# Altium schematic sheet MIPI.SchDoc
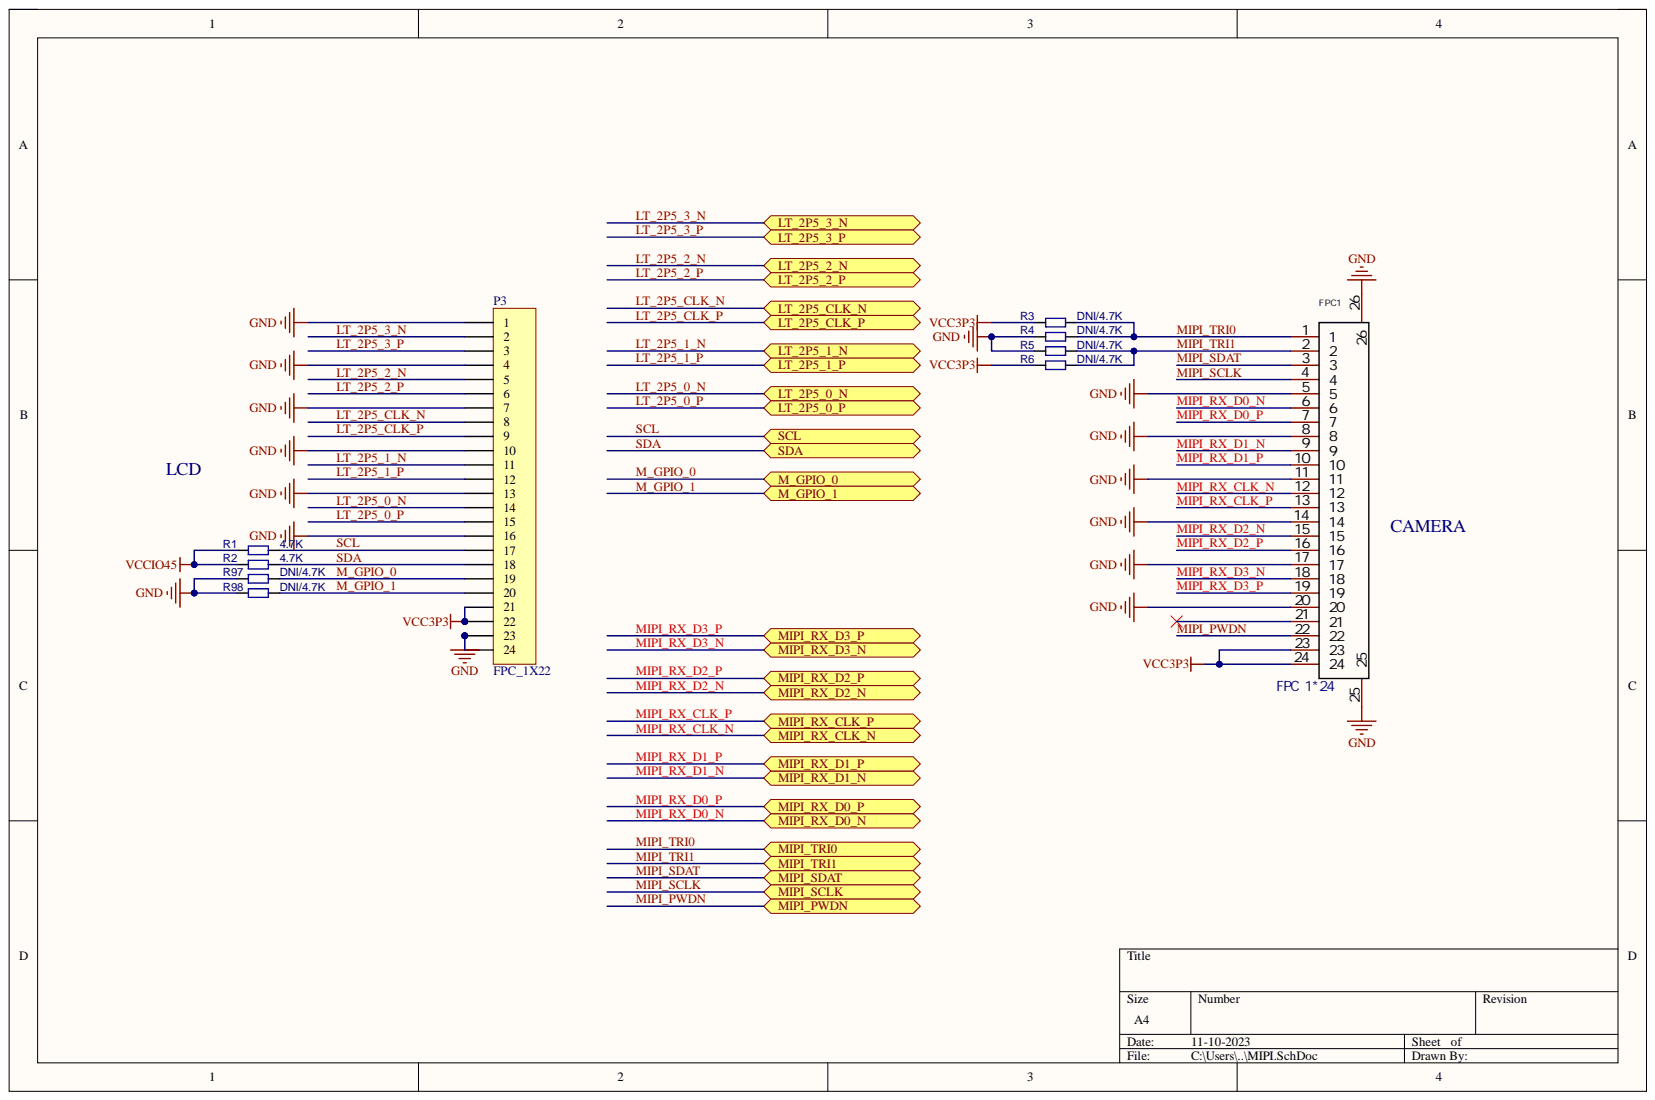
[diagram p0: MIPI.SchDoc]

## Parts
FPC1  FPC 1*24  [FPC24-0R5MM]
P3  FPC_1X22  [FPC22-0R5MM]
R1  4.7K  [R0402]
R2  4.7K  [R0402]
R3  DNI/4.7K  [R0402]
R4  DNI/4.7K  [R0402]
R5  DNI/4.7K  [R0402]
R6  DNI/4.7K  [R0402]
R97  DNI/4.7K  [R0402]
R98  DNI/4.7K  [R0402]

## Ports
LT_2P5_0_N, LT_2P5_0_P, LT_2P5_1_N, LT_2P5_1_P, LT_2P5_2_N, LT_2P5_2_P, LT_2P5_3_N, LT_2P5_3_P, LT_2P5_CLK_N, LT_2P5_CLK_P, M_GPIO_0, M_GPIO_1, MIPI_PWDN, MIPI_RX_CLK_N, MIPI_RX_CLK_P, MIPI_RX_D0_N, MIPI_RX_D0_P, MIPI_RX_D1_N, MIPI_RX_D1_P, MIPI_RX_D2_N, MIPI_RX_D2_P, MIPI_RX_D3_N, MIPI_RX_D3_P, MIPI_SCLK, MIPI_SDAT, MIPI_TRI0, MIPI_TRI1, SCL, SDA

## Nets
GND: FPC1.5 FPC1.8 FPC1.11 FPC1.14 FPC1.17 FPC1.20 FPC1.25 FPC1.26 P3.1 P3.4 P3.7 P3.10 P3.13 P3.16 P3.23 P3.24 R4.1 R5.1 R97.1 R98.1
VCC3P3: FPC1.23 FPC1.24 P3.21 P3.22 R3.1 R6.1
VCCIO45: R1.1 R2.1
LT_2P5_0_N: P3.14 ; port LT_2P5_0_N
LT_2P5_0_P: P3.15 ; port LT_2P5_0_P
LT_2P5_1_N: P3.11 ; port LT_2P5_1_N
LT_2P5_1_P: P3.12 ; port LT_2P5_1_P
LT_2P5_2_N: P3.5 ; port LT_2P5_2_N
LT_2P5_2_P: P3.6 ; port LT_2P5_2_P
LT_2P5_3_N: P3.2 ; port LT_2P5_3_N
LT_2P5_3_P: P3.3 ; port LT_2P5_3_P
LT_2P5_CLK_N: P3.8 ; port LT_2P5_CLK_N
LT_2P5_CLK_P: P3.9 ; port LT_2P5_CLK_P
M_GPIO_0: P3.19 R97.2 ; port M_GPIO_0
M_GPIO_1: P3.20 R98.2 ; port M_GPIO_1
MIPI_PWDN: FPC1.22 ; port MIPI_PWDN
MIPI_RX_CLK_N: FPC1.12 ; port MIPI_RX_CLK_N
MIPI_RX_CLK_P: FPC1.13 ; port MIPI_RX_CLK_P
MIPI_RX_D0_N: FPC1.6 ; port MIPI_RX_D0_N
MIPI_RX_D0_P: FPC1.7 ; port MIPI_RX_D0_P
MIPI_RX_D1_N: FPC1.9 ; port MIPI_RX_D1_N
MIPI_RX_D1_P: FPC1.10 ; port MIPI_RX_D1_P
MIPI_RX_D2_N: FPC1.15 ; port MIPI_RX_D2_N
MIPI_RX_D2_P: FPC1.16 ; port MIPI_RX_D2_P
MIPI_RX_D3_N: FPC1.18 ; port MIPI_RX_D3_N
MIPI_RX_D3_P: FPC1.19 ; port MIPI_RX_D3_P
MIPI_SCLK: FPC1.4 ; port MIPI_SCLK
MIPI_SDAT: FPC1.3 ; port MIPI_SDAT
MIPI_TRI0: FPC1.1 R3.2 R4.2 ; port MIPI_TRI0
MIPI_TRI1: FPC1.2 R5.2 R6.2 ; port MIPI_TRI1
SCL: P3.17 R1.2 ; port SCL
SDA: P3.18 R2.2 ; port SDA

Not connected: FPC1 21

## Library data of the parts
FPC1 = AFC01-S24FCA-00: Manufacturer: JS(钜硕电子); Add into BOM: yes; FFC, FCB Thickness: 0.3mm; Locking Feature: 翻盖式; Number of Contacts: 24P; Pitch: 0.5mm; Component Kind: Standard; Contact Type: 下接; Convert to PCB: yes; Datasheet: https://atta.szlcsc.com/upload/public/pdf/source/20190816/C413942_2A72D1AC756EAE17EEDF756A339D4500.pdf; Description: FPC 1*24; Device: AFC01-S24FCA-00; Height Above Board: 2mm; JLCPCB Part Class: Extended Part; LCSC Part Name: 0.5mm P数:24P 翻盖式 下接; Manufacturer Part: AFC01-S24FCA-00; Mounting Type: 卧贴; Name: AFC01-S24FCA-00; PCB3D: FPC-SMD_P0.50-WS-24P; Supplier: LCSC; Supplier Footprint: SMD,P=0.5mm; Supplier Part: C262669; Symbol: AFC01-S24FCA-00
P3 = Header 24: FPC 1*22; Component Kind: Standard; LatestRevisionDate: 17-Jul-2002; LatestRevisionNote: Re-released for DXP Platform.; Library Name: Miscellaneous Connectors.IntLib; Signal Integrity: Connector; Publisher: Altium Limited
R1-R6 R97 R98 = R: Value: 4K7; Component Kind: Standard; Library Name: zynq.SCHLIB
